# Altium schematic sheet Switch-Control.SchDoc
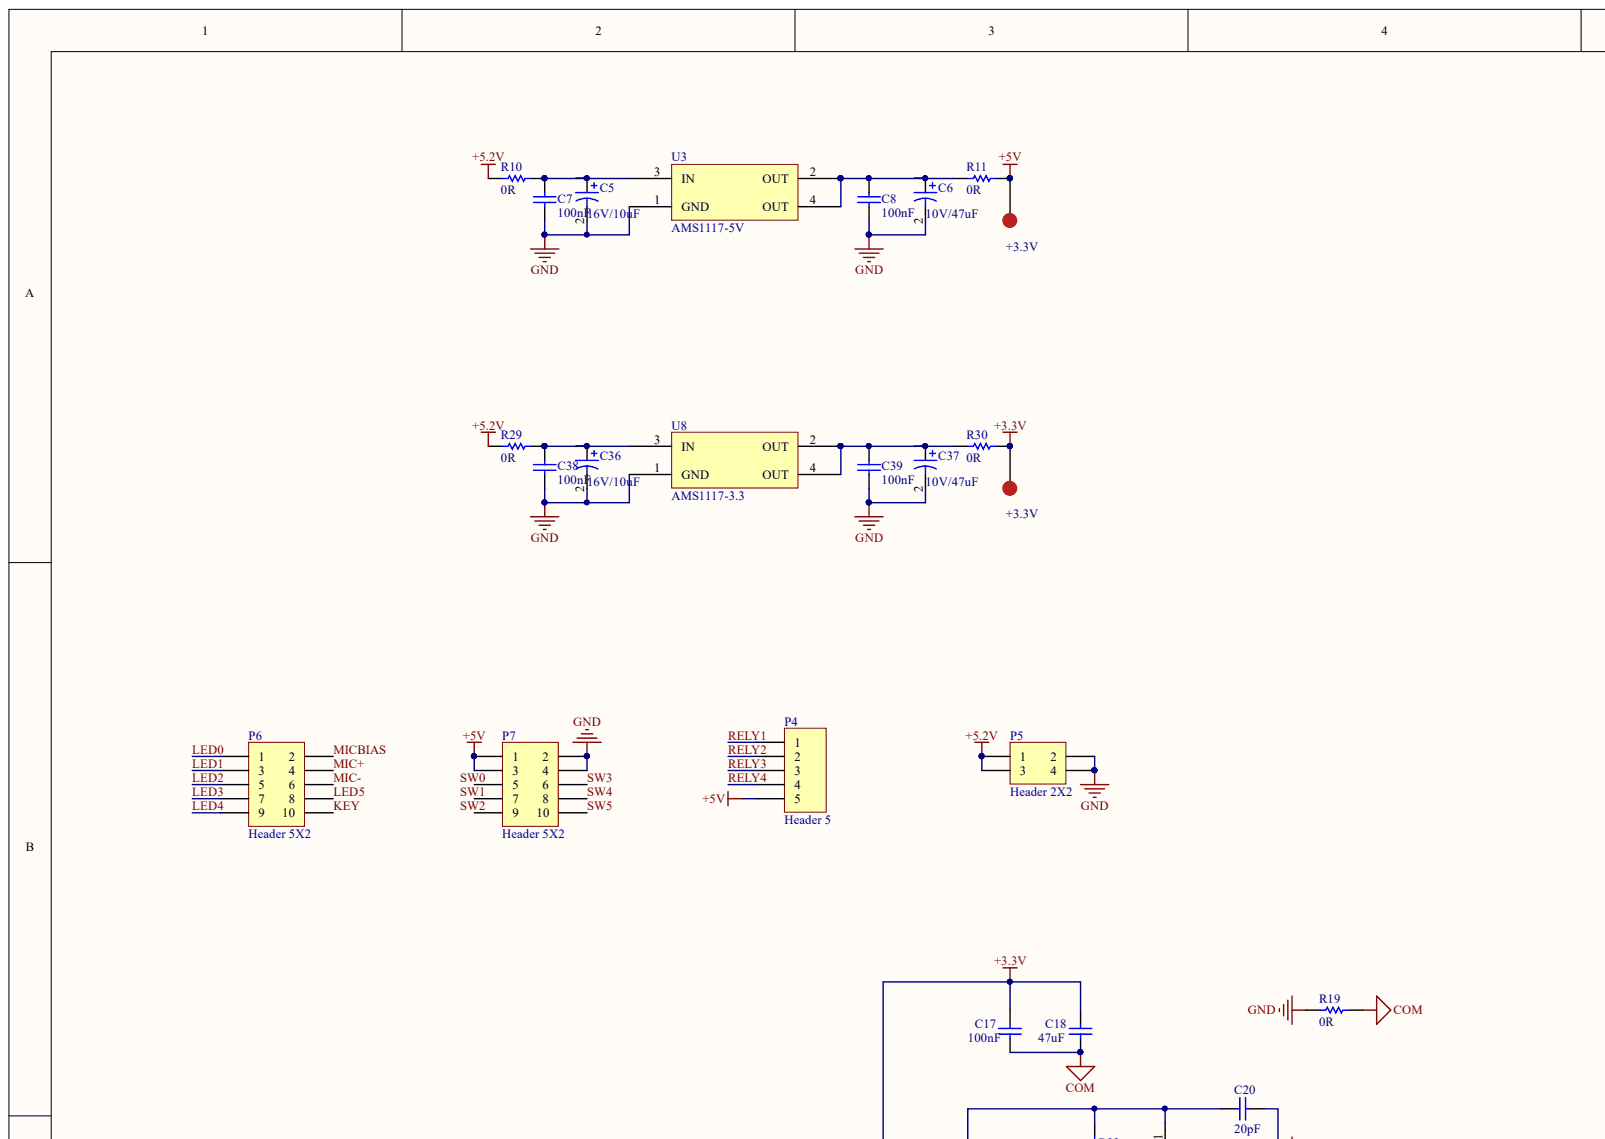
[diagram p0: Switch-Control.SchDoc, top left]
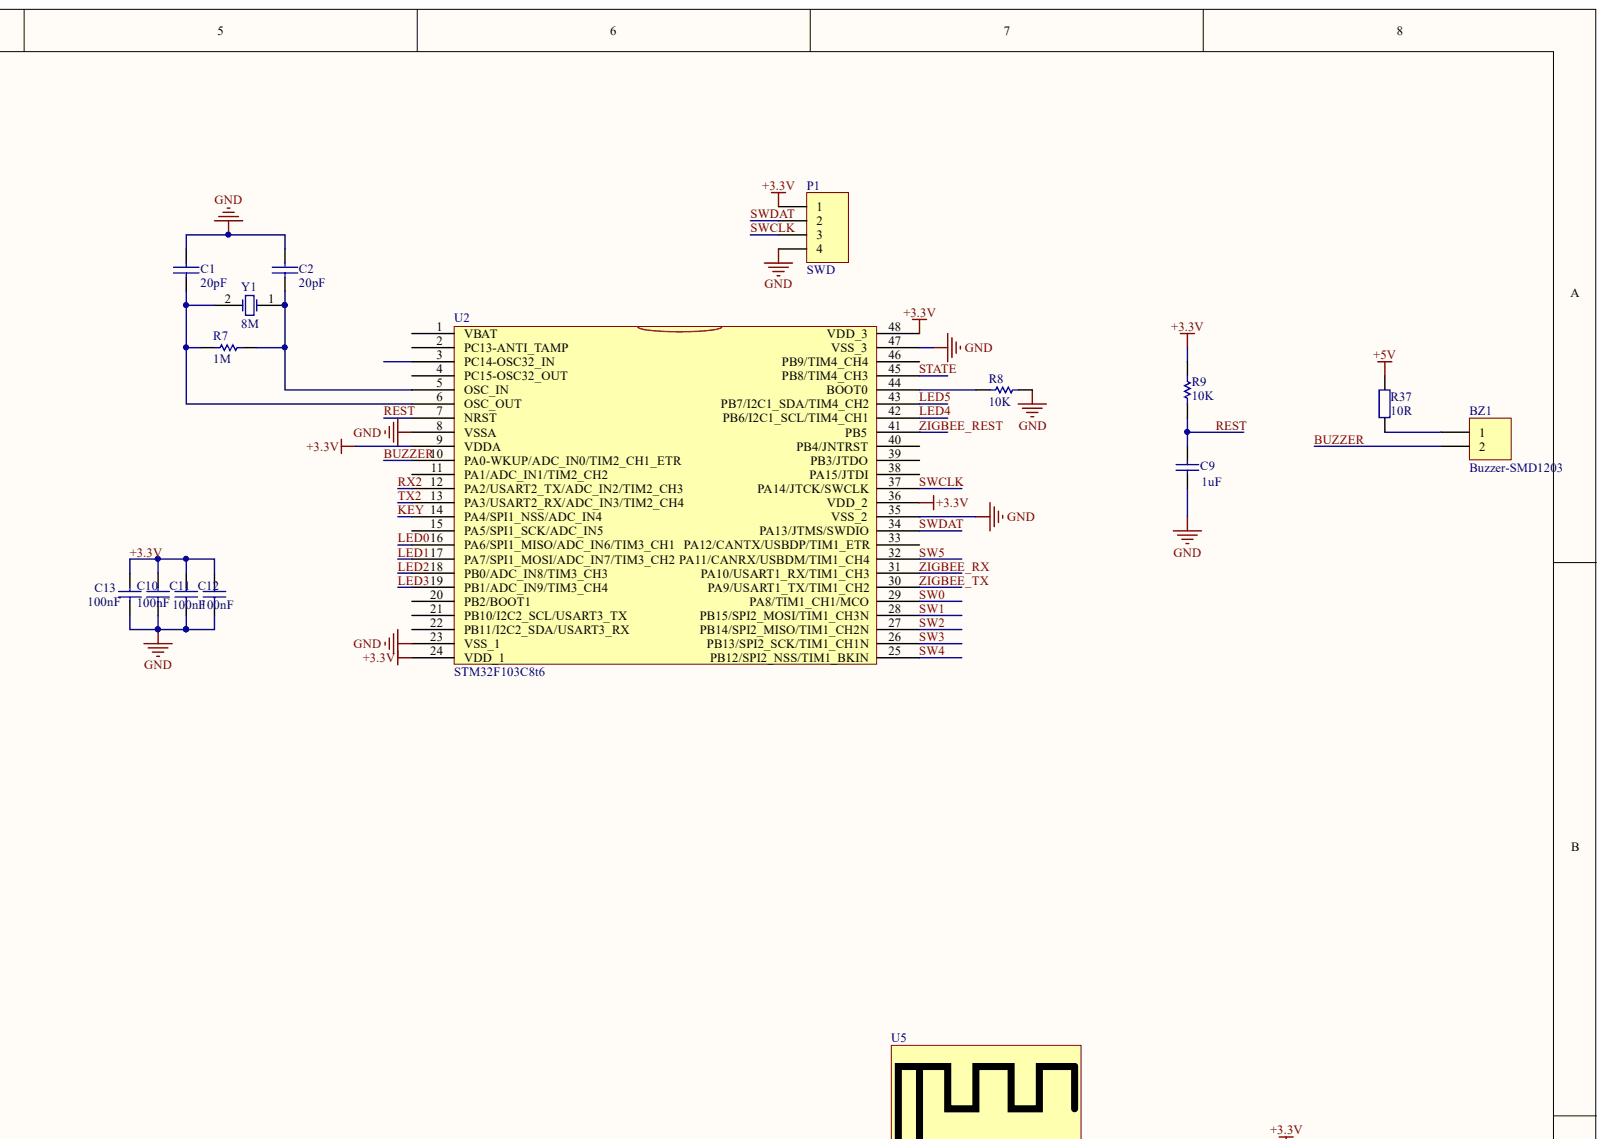
[diagram p1: Switch-Control.SchDoc, top right]
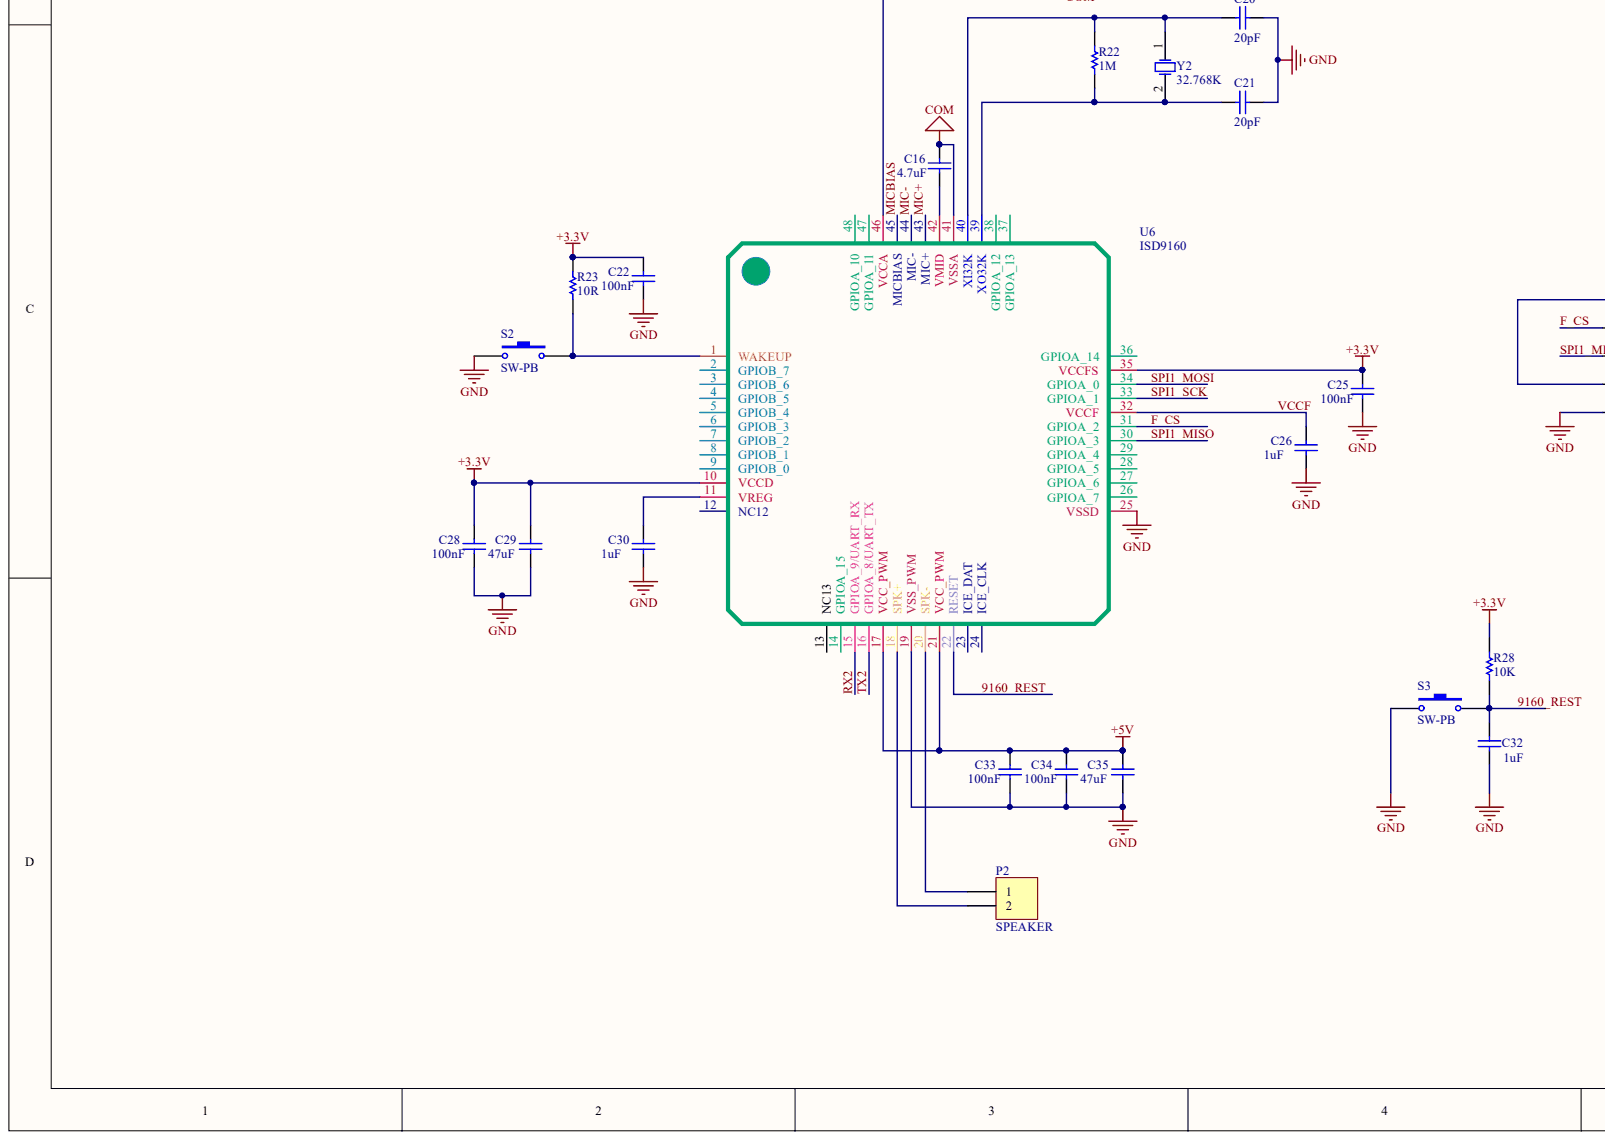
[diagram p2: Switch-Control.SchDoc, bottom left]
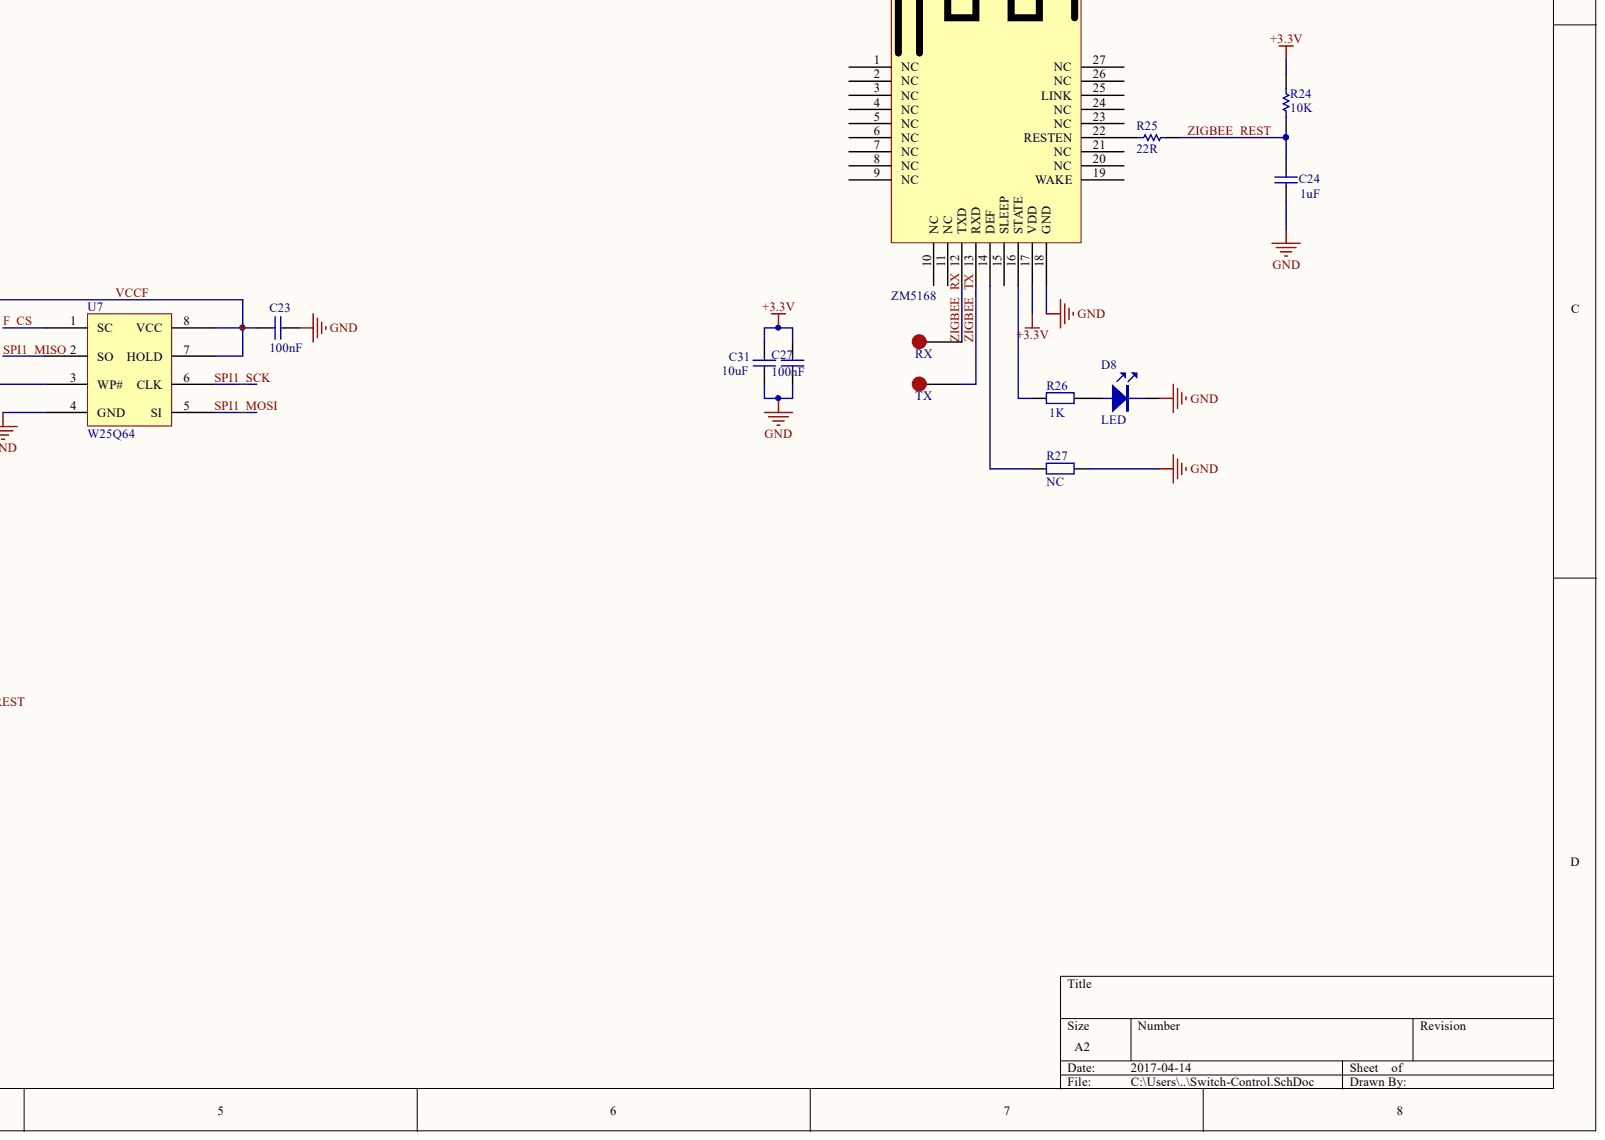
[diagram p3: Switch-Control.SchDoc, bottom right]

## Parts
BZ1  Buzzer-SMD1203
C1  20pF
C2  20pF
C5  16V/10uF
C6  10V/47uF
C7  100nF
C8  100nF
C9  1uF
C10  100nF
C11  100nF
C12  100nF
C13  100nF
C16  4.7uF
C17  100nF
C18  47uF
C20  20pF
C21  20pF
C22  100nF
C23  100nF
C24  1uF
C25  100nF
C26  1uF
C27  100nF
C28  100nF
C29  47uF
C30  1uF
C31  10uF
C32  1uF
C33  100nF
C34  100nF
C35  47uF
C36  16V/10uF
C37  10V/47uF
C38  100nF
C39  100nF
D8  LED
P1  SWD
P2  SPEAKER
P4  Header 5
P5  Header 2X2
P6  Header 5X2
P7  Header 5X2
R7  1M
R8  10K
R9  10K
R10  0R
R11  0R
R19  0R
R22  1M
R23  10R
R24  10K
R25  22R
R26  1K
R27  NC
R28  10K
R29  0R
R30  0R
R37  10R
S2  SW-PB
S3  SW-PB
T7  +3.3V
T8  RX
T9  TX
T10  +3.3V
U2  STM32F103C8t6
U3  AMS1117-5V
U5  ZM5168
U6  ISD9160
U7  W25Q64
U8  AMS1117-3.3
Y1  8M
Y2  32.768K

## Nets
+5.2V: P5.1 P5.3 R10.1 R29.1
+5V: C33.2 C34.2 C35.2 P4.5 P7.1 P7.3 R11.2 R37.2 T7.1 U6.17(VCC_PWM) U6.21(VCC_PWM)
COM: C16.2 C17.1 C18.1 R19.2 U6.41(VSSA)
GND: C1.2 C2.2 C5.2 C6.2 C7.1 C8.1 C9.2 C10.1 C11.1 C12.1 C13.1 C20.1 C21.1 C22.1 C23.2 C24.2 C25.1 C26.1 C27.1 C28.1 C29.1 C30.1 C31.1 C32.2 C33.1 C34.1 C35.1 C36.2 C37.2 C38.1 C39.1 D8.2 P1.4 P5.2 P5.4 P7.2 P7.4 R8.1 R19.1 R27.1 S2.1 S3.1 U2.8(VSSA) U2.23(VSS_1) U2.35(VSS_2) U2.47(VSS_3) U3.1(GND) U5.18(GND) U6.19(VSS_PWM) U6.25(VSSD) U7.4(GND) U8.1(GND)
9160_REST: C32.1 R28.2 S3.2 U6.22(RESET)
+3.3V: C10.2 C11.2 C12.2 C13.2 C17.2 C18.2 C22.2 C25.2 C27.2 C28.2 C29.2 C31.2 P1.1 R9.1 R23.2 R24.1 R28.1 R30.2 T10.1 U2.9(VDDA) U2.24(VDD_1) U2.36(VDD_2) U2.48(VDD_3) U5.17(VDD) U6.10(VCCD) U6.35(VCCFS) U6.46(VCCA)
BUZZER: BZ1.2 U2.10(PA0-WKUP/ADC_IN0/TIM2_CH1_ETR)
F_CS: U6.31(GPIOA_2) U7.1(SC)
KEY: P6.10 U2.14(PA4/SPI1_NSS/ADC_IN4)
LED0: P6.1 U2.16(PA6/SPI1_MISO/ADC_IN6/TIM3_CH1)
LED1: P6.3 U2.17(PA7/SPI1_MOSI/ADC_IN7/TIM3_CH2)
LED2: P6.5 U2.18(PB0/ADC_IN8/TIM3_CH3)
LED3: P6.7 U2.19(PB1/ADC_IN9/TIM3_CH4)
LED4: P6.9 U2.42(PB6/I2C1_SCL/TIM4_CH1)
LED5: P6.8 U2.43(PB7/I2C1_SDA/TIM4_CH2)
MIC+: P6.4 U6.43(MIC+)
MIC-: P6.6 U6.44(MIC-)
MICBIAS: P6.2 U6.45(MICBIAS)
RELY1: P4.1
RELY2: P4.2
RELY3: P4.3
RELY4: P4.4
REST: C9.1 R9.2 U2.7(NRST)
RX2: U2.12(PA2/USART2_TX/ADC_IN2/TIM2_CH3) U6.15(GPIOA_9/UART_RX)
SPI1_MISO: U6.30(GPIOA_3) U7.2(SO)
SPI1_MOSI: U6.34(GPIOA_0) U7.5(SI)
SPI1_SCK: U6.33(GPIOA_1) U7.6(CLK)
STATE: U2.45(PB8/TIM4_CH3)
SW0: U2.29(PA8/TIM1_CH1/MCO)
SW1: U2.28(PB15/SPI2_MOSI/TIM1_CH3N)
SW2: U2.27(PB14/SPI2_MISO/TIM1_CH2N)
SW3: P7.6 U2.26(PB13/SPI2_SCK/TIM1_CH1N)
SW4: P7.8 U2.25(PB12/SPI2_NSS/TIM1_BKIN)
SW5: P7.10 U2.32(PA11/CANRX/USBDM/TIM1_CH4)
SWCLK: P1.3 U2.37(PA14/JTCK/SWCLK)
SWDAT: P1.2 U2.34(PA13/JTMS/SWDIO)
TX2: U2.13(PA3/USART2_RX/ADC_IN3/TIM2_CH4) U6.16(GPIOA_8/UART_TX)
VCCF: C23.1 C26.2 U6.32(VCCF) U7.3(WP#) U7.7(HOLD) U7.8(VCC)
ZIGBEE_REST: C24.1 R24.2 R25.1 U2.41(PB5)
ZIGBEE_RX: T8.1 U2.31(PA10/USART1_RX/TIM1_CH3) U5.12(TXD)
ZIGBEE_TX: T9.1 U2.30(PA9/USART1_TX/TIM1_CH2) U5.13(RXD)
NetBZ1_1: BZ1.1 R37.1
NetC1_1: C1.1 R7.2 U2.6(OSC_OUT) Y1.2
NetC2_1: C2.1 R7.1 U2.5(OSC_IN) Y1.1
NetC5_1: C5.1 C7.2 R10.2 U3.3(IN)
NetC6_1: C6.1 C8.2 R11.1 U3.2(OUT) U3.4(OUT)
NetC16_1: C16.1 U6.42(VMID)
NetC20_2: C20.2 R22.2 U6.40(XI32K) Y2.1
NetC21_2: C21.2 R22.1 U6.39(XO32K) Y2.2
NetC30_2: C30.2 U6.11(VREG)
NetC36_1: C36.1 C38.2 R29.2 U8.3(IN)
NetC37_1: C37.1 C39.2 R30.1 U8.2(OUT) U8.4(OUT)
NetD8_1: D8.1 R26.2
NetP2_1: P2.1 U6.20(SPK-)
NetP2_2: P2.2 U6.18(SPK+)
NetR8_2: R8.2 U2.44(BOOT0)
NetR23_1: R23.1 S2.2 U6.1(WAKEUP)
NetR25_2: R25.2 U5.22(RESTEN)
NetR26_1: R26.1 U5.16(STATE)
NetR27_2: R27.2 U5.14(DEF)

Not connected: P7 5 7 9; U2 1(VBAT) 2(PC13-ANTI_TAMP) 3(PC14-OSC32_IN) 4(PC15-OSC32_OUT) 11(PA1/ADC_IN1/TIM2_CH2) 15(PA5/SPI1_SCK/ADC_IN5) 20(PB2/BOOT1) 21(PB10/I2C2_SCL/USART3_TX) 22(PB11/I2C2_SDA/USART3_RX) 33(PA12/CANTX/USBDP/TIM1_ETR) 38(PA15/JTDI) 39(PB3/JTDO) 40(PB4/JNTRST) 46(PB9/TIM4_CH4); U5 1(NC) 2(NC) 3(NC) 4(NC) 5(NC) 6(NC) 7(NC) 8(NC) 9(NC) 10(NC) 11(NC) 15(SLEEP) 19(WAKE) 20(NC) 21(NC) 23(NC) 24(NC) 25(LINK) 26(NC) 27(NC); U6 2(GPIOB_7) 3(GPIOB_6) 4(GPIOB_5) 5(GPIOB_4) 6(GPIOB_3) 7(GPIOB_2) 8(GPIOB_1) 9(GPIOB_0) 12(NC12) 13(NC13) 14(GPIOA_15) 23(ICE_DAT) 24(ICE_CLK) 26(GPIOA_7) 27(GPIOA_6) 28(GPIOA_5) 29(GPIOA_4) 36(GPIOA_14) 37(GPIOA_13) 38(GPIOA_12) 47(GPIOA_11) 48(GPIOA_10)

## Library data of the parts
C5-C8 C20 C21 C23 C36-C39 = (no symbol name): Value: 100pF; Published: 8-Jun-2000; LatestRevisionDate: 17-Jul-2002; LatestRevisionNote: Re-released for DXP Platform.; Publisher: Altium Limited
  C5 C6 C36 C37: PackageReference: RB7.6-15; PackageDescription: Polarized Capacitor (Radial); 2 Leads
  C7 C8 C20 C21 C23 C38 C39: PackageReference: RAD-0.3; PackageDescription: Radial Cap, Thru-Hole; 2 Leads; 0.3 in Pin Spacing
C9-C13 C16-C18 C22 C24-C35 = (no symbol name): Value: 0.1uF; Published: 8-Jun-2000; LatestRevisionDate: 17-Jul-2002; LatestRevisionNote: Re-released for DXP Platform.; PackageReference: RAD-0.3; Publisher: Altium Limited
R7-R11 R19 R22-R25 R27-R30 = (no symbol name): Value: 1K; Published: 8-Jun-2000; LatestRevisionDate: 17-Jul-2002; LatestRevisionNote: Re-released for DXP Platform.; Publisher: Altium Limited
  R7-R11 R19 R22-R25 R28-R30: PackageReference: AXIAL-0.3; PackageDescription: Axial Device, Thru-Hole; 2 Leads; 0.3 in Pin Spacing
  R27: PackageReference: AXIAL-0.4; PackageDescription: Axial Device, Thru-Hole; 2 Leads; 0.4 in Pin Spacing
BZ1 C1 C2 D8 P1 P2 P4-P7 R26 R37 S2 S3 T7-T10 U2 U3 U5-U8 Y1 Y2 = (no symbol name)
  BZ1 P1 P2 P4-P7: LatestRevisionDate: 17-Jul-2002; LatestRevisionNote: Re-released for DXP Platform.
  R26: Published: 8-Jun-2000; LatestRevisionDate: 17-Jul-2002; LatestRevisionNote: Re-released for DXP Platform.; PackageReference: AXIAL-0.4; PackageDescription: Axial Device, Thru-Hole; 2 Leads; 0.4 in Pin Spacing; Value: 1K
  R37: Published: 8-Jun-2000; LatestRevisionDate: 17-Jul-2002; LatestRevisionNote: Re-released for DXP Platform.; PackageReference: AXIAL-0.4; PackageDescription: Axial Device, Thru-Hole; 2 Leads; 0.4 in Pin Spacing; Value: 10R
  S2 S3: Published: 24-Mar-1999; LatestRevisionDate: 17-Jul-2002; LatestRevisionNote: Re-released for DXP Platform.; PackageReference: SPST-2; PackageDescription: Switch; 2 Leads
  Y1: Published: 8-Jun-2000; LatestRevisionDate: 17-Jul-2002; LatestRevisionNote: Re-released for DXP Platform.; PackageReference: R38
  Y2: Published: 8-Jun-2000; LatestRevisionDate: 17-Jul-2002; LatestRevisionNote: Re-released for DXP Platform.
  BZ1 P1 P2 P4-P7 R26 R37 S2 S3 Y1 Y2: Publisher: Altium Limited
